# Altium schematic sheet ERM_OPTO_Long.SchDoc(ERM_OPTO_Long)
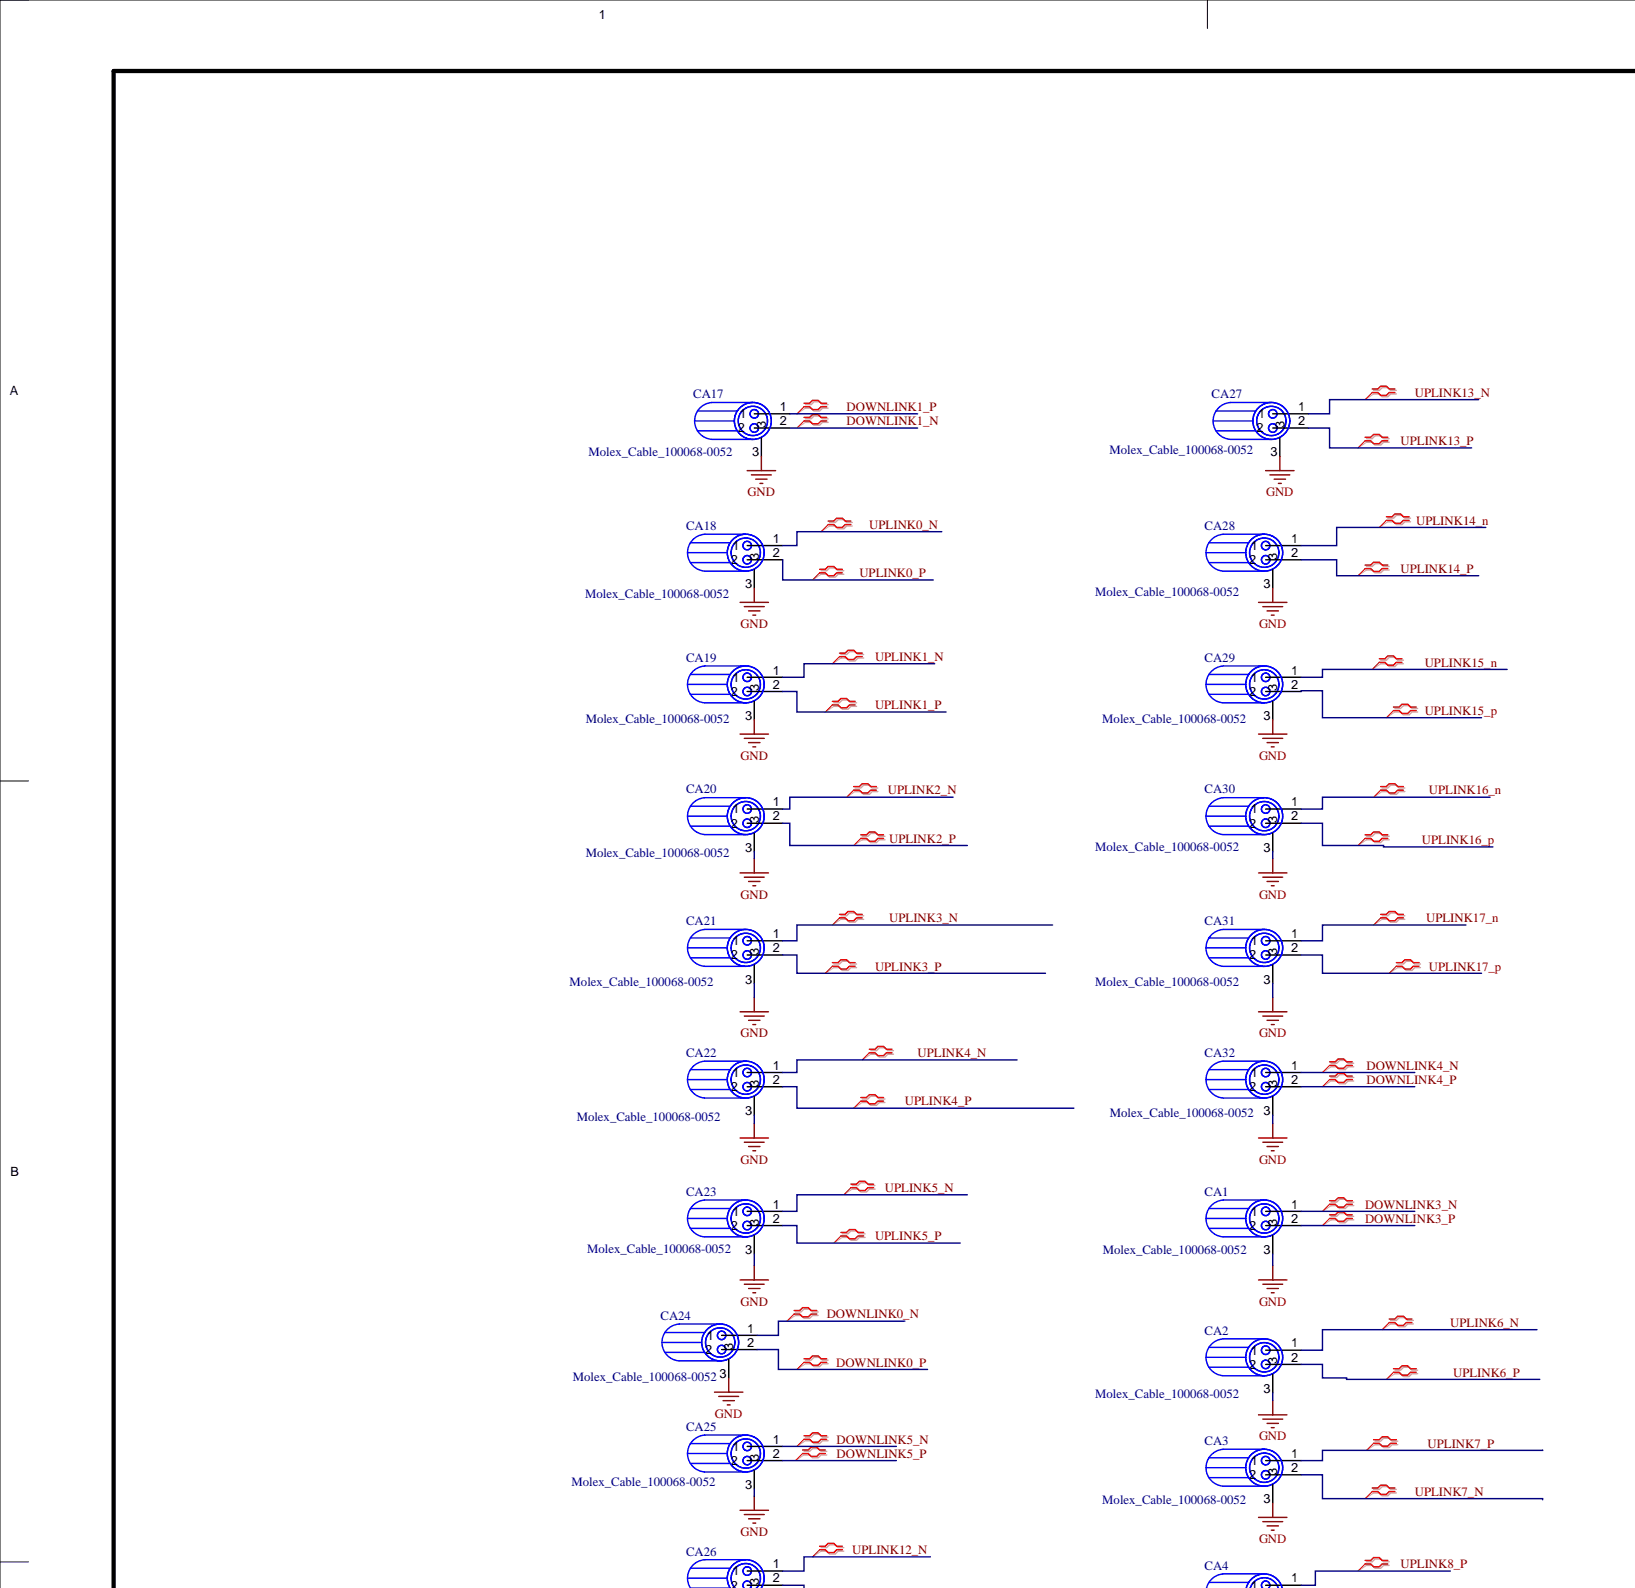
[diagram p0: ERM_OPTO_Long.SchDoc, top left]
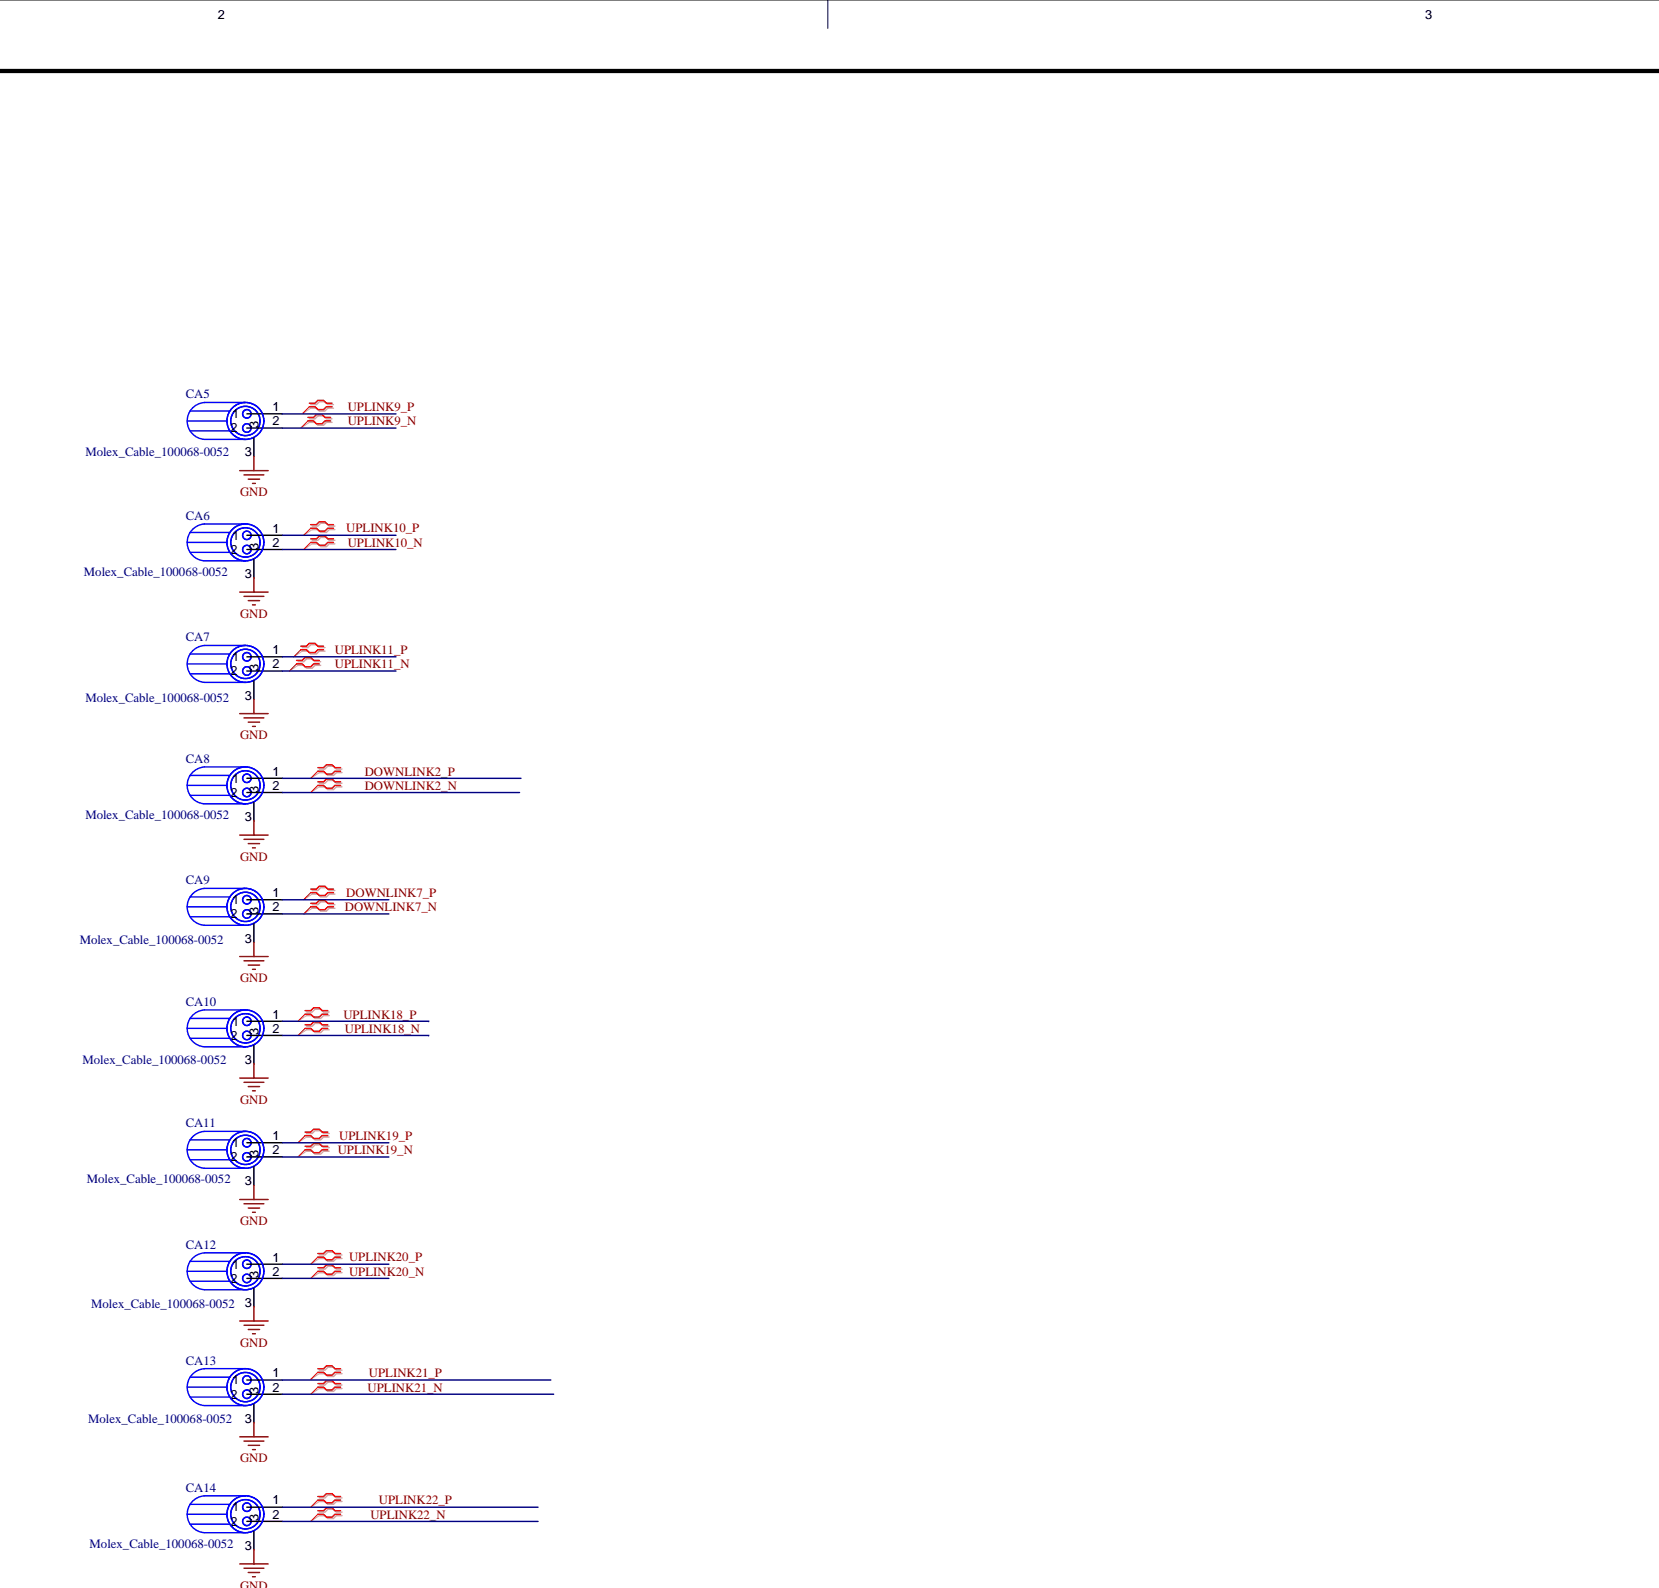
[diagram p1: ERM_OPTO_Long.SchDoc, top centre]
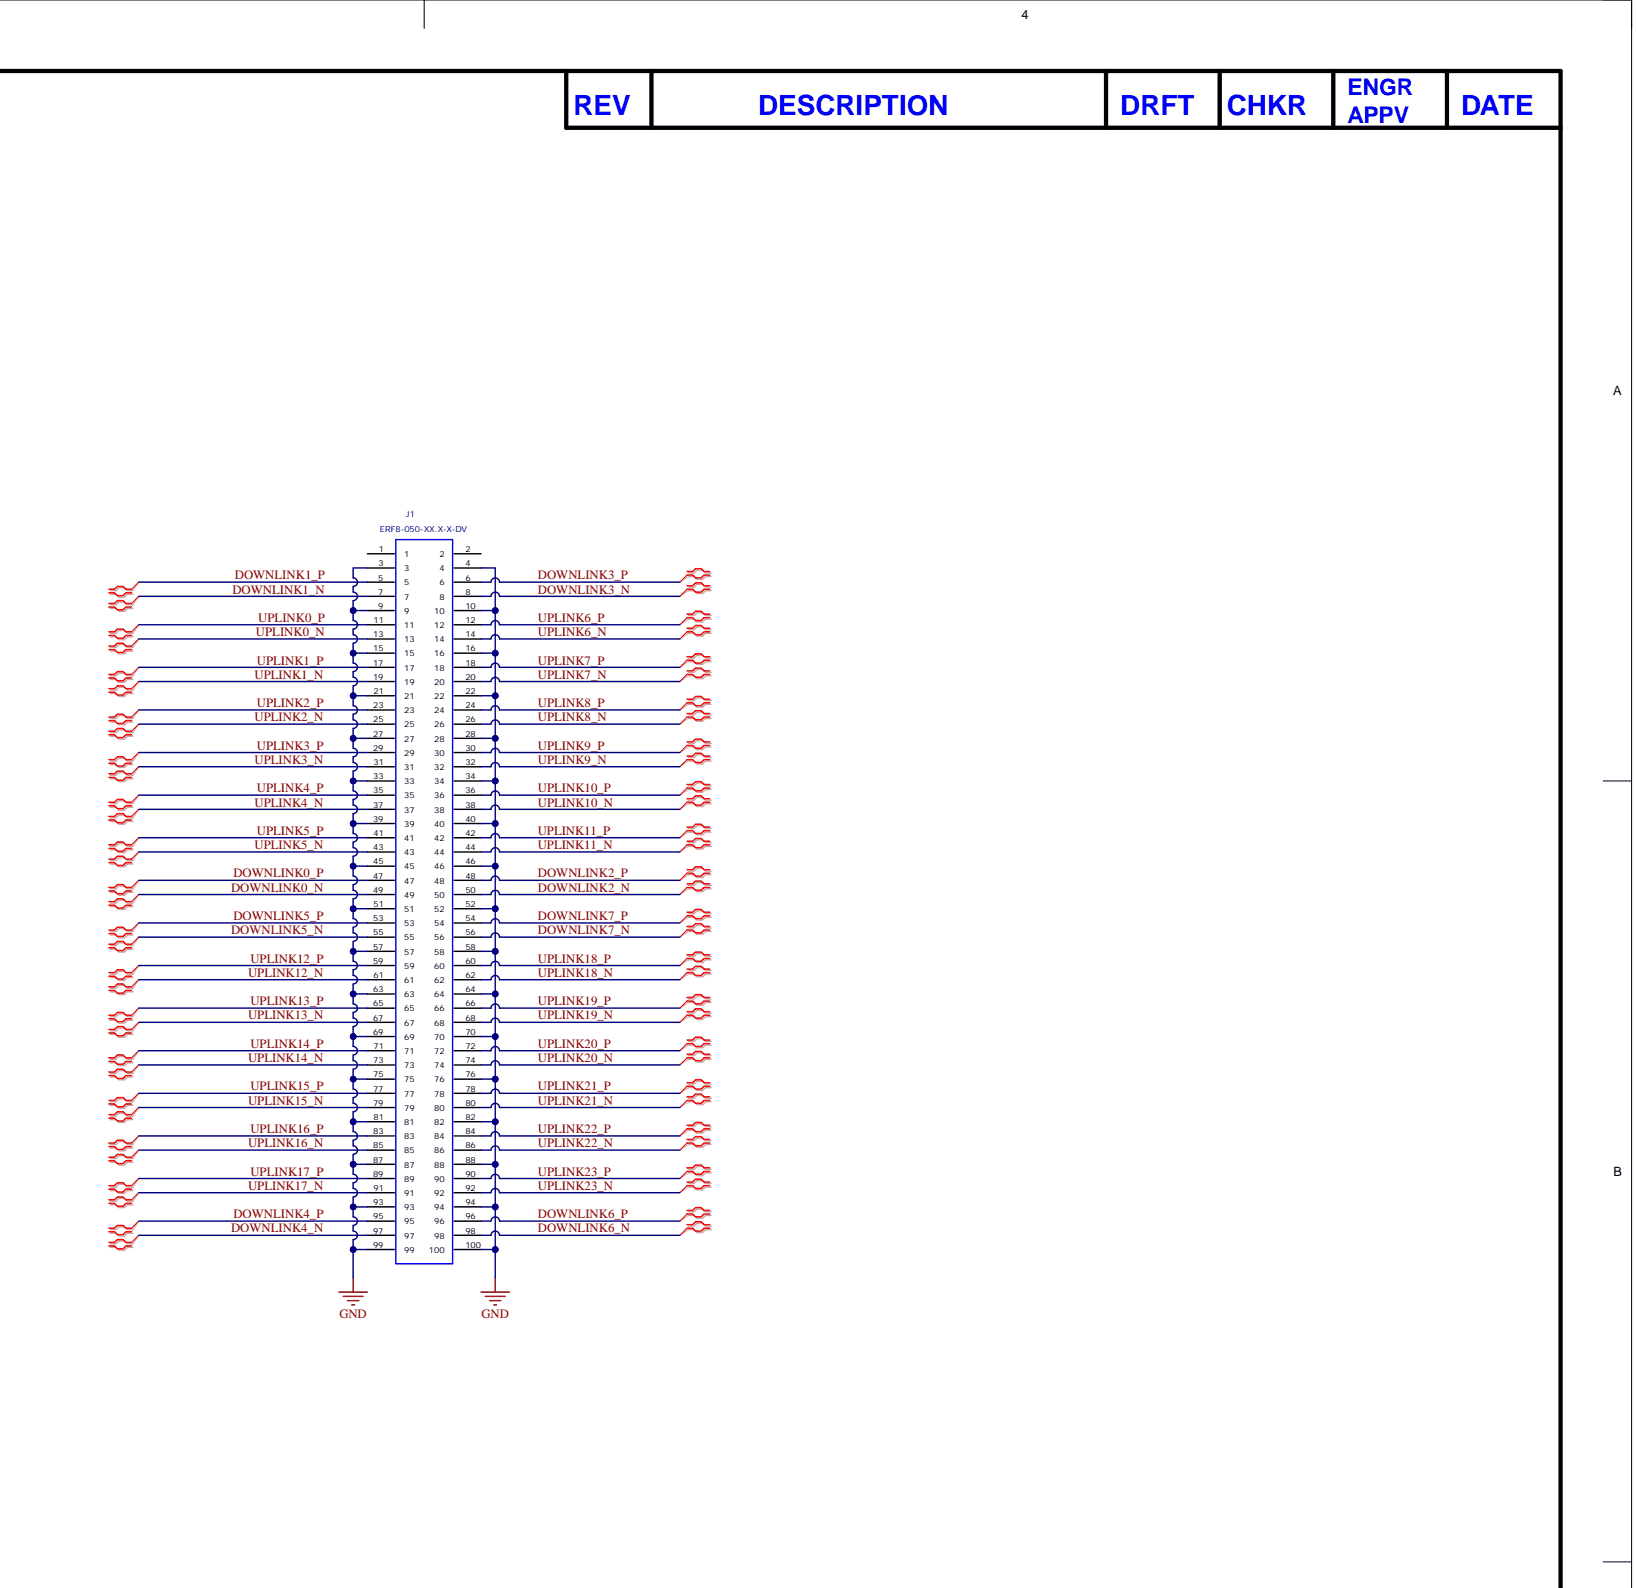
[diagram p2: ERM_OPTO_Long.SchDoc, top right]
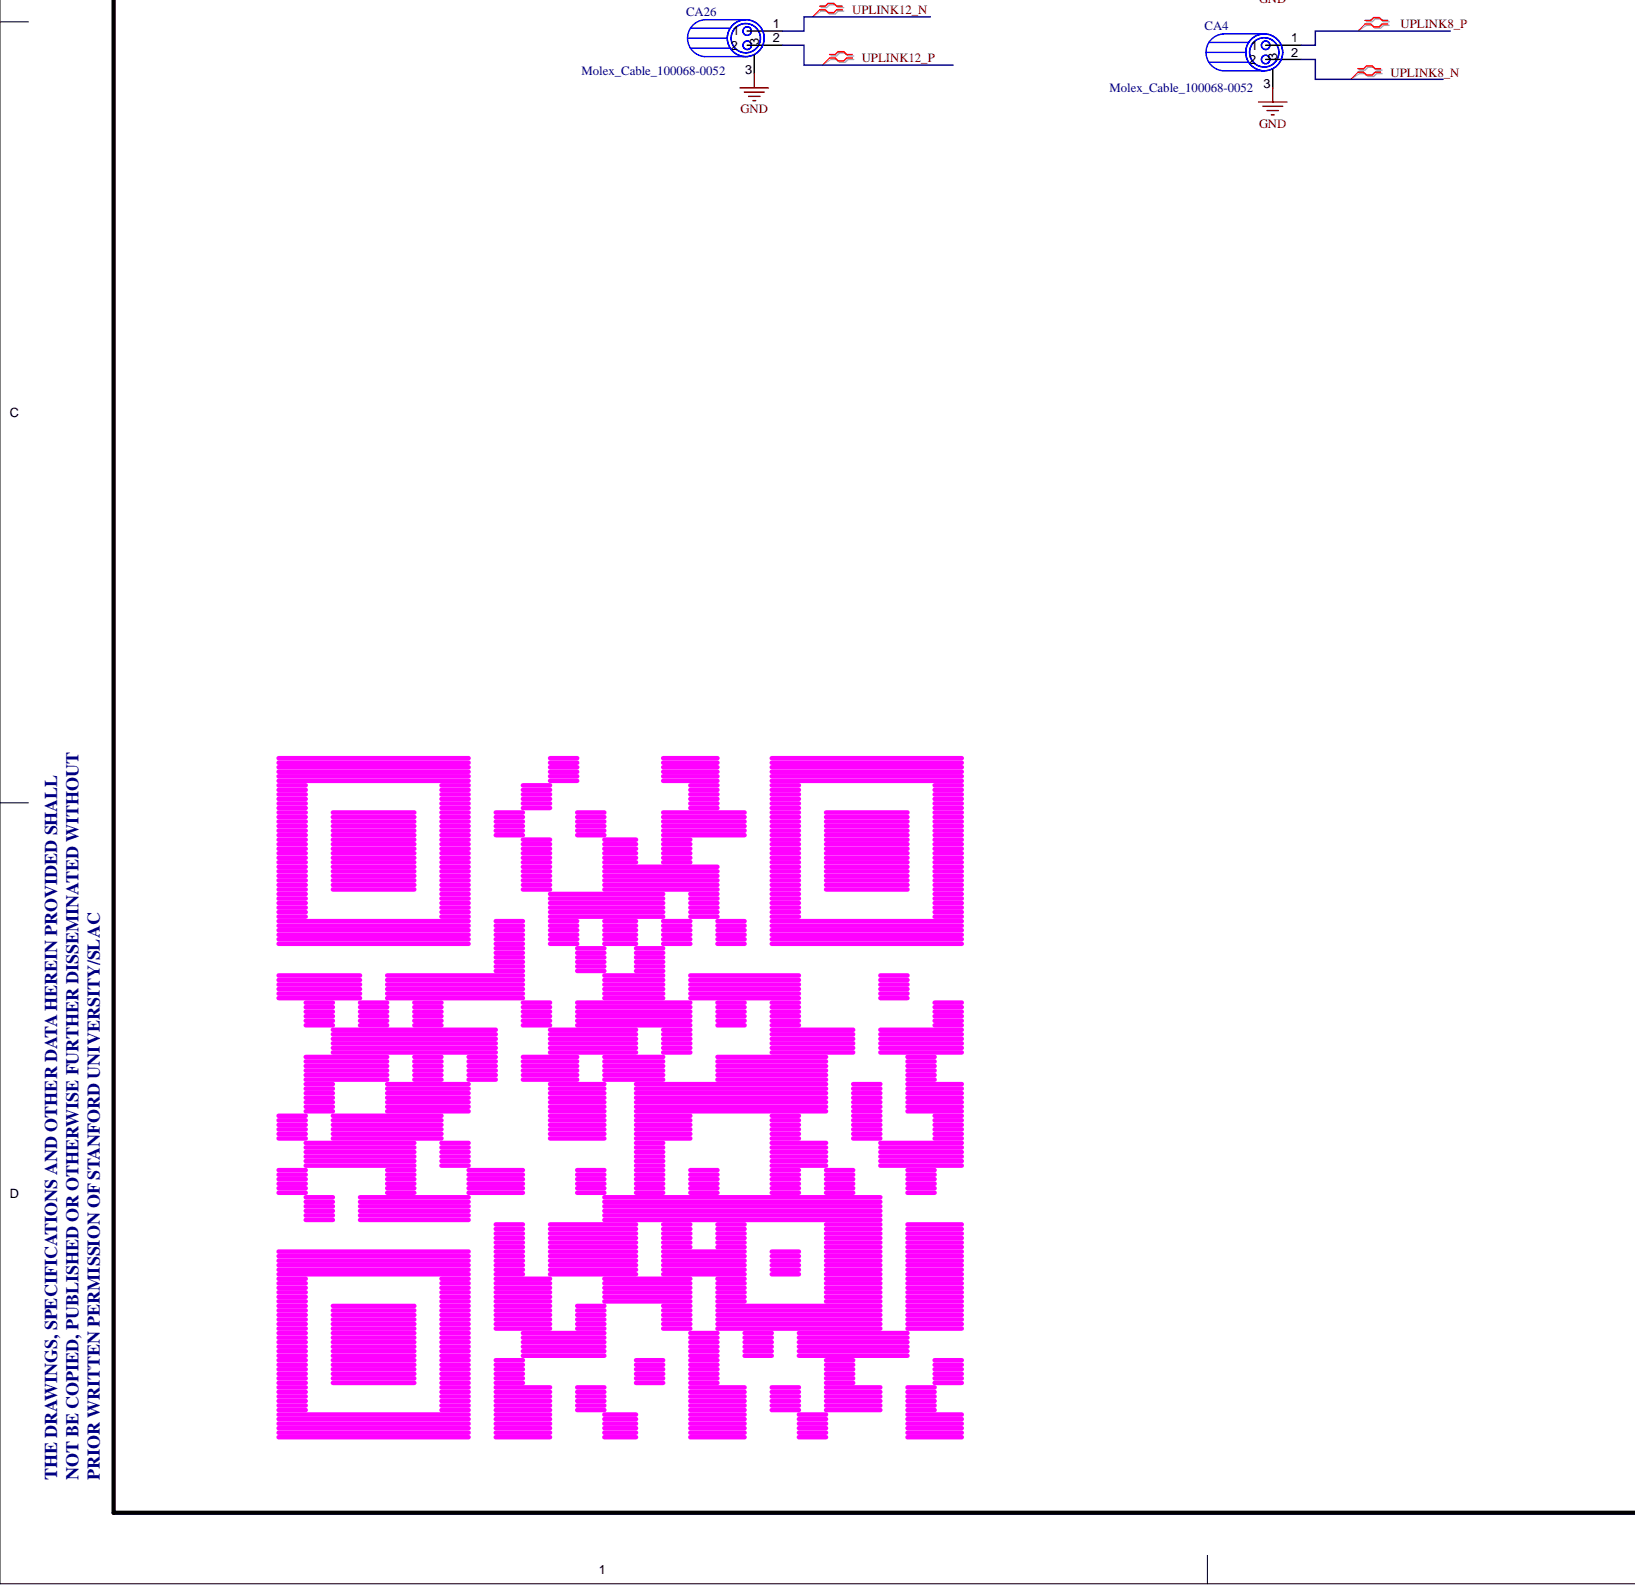
[diagram p3: ERM_OPTO_Long.SchDoc, bottom left]
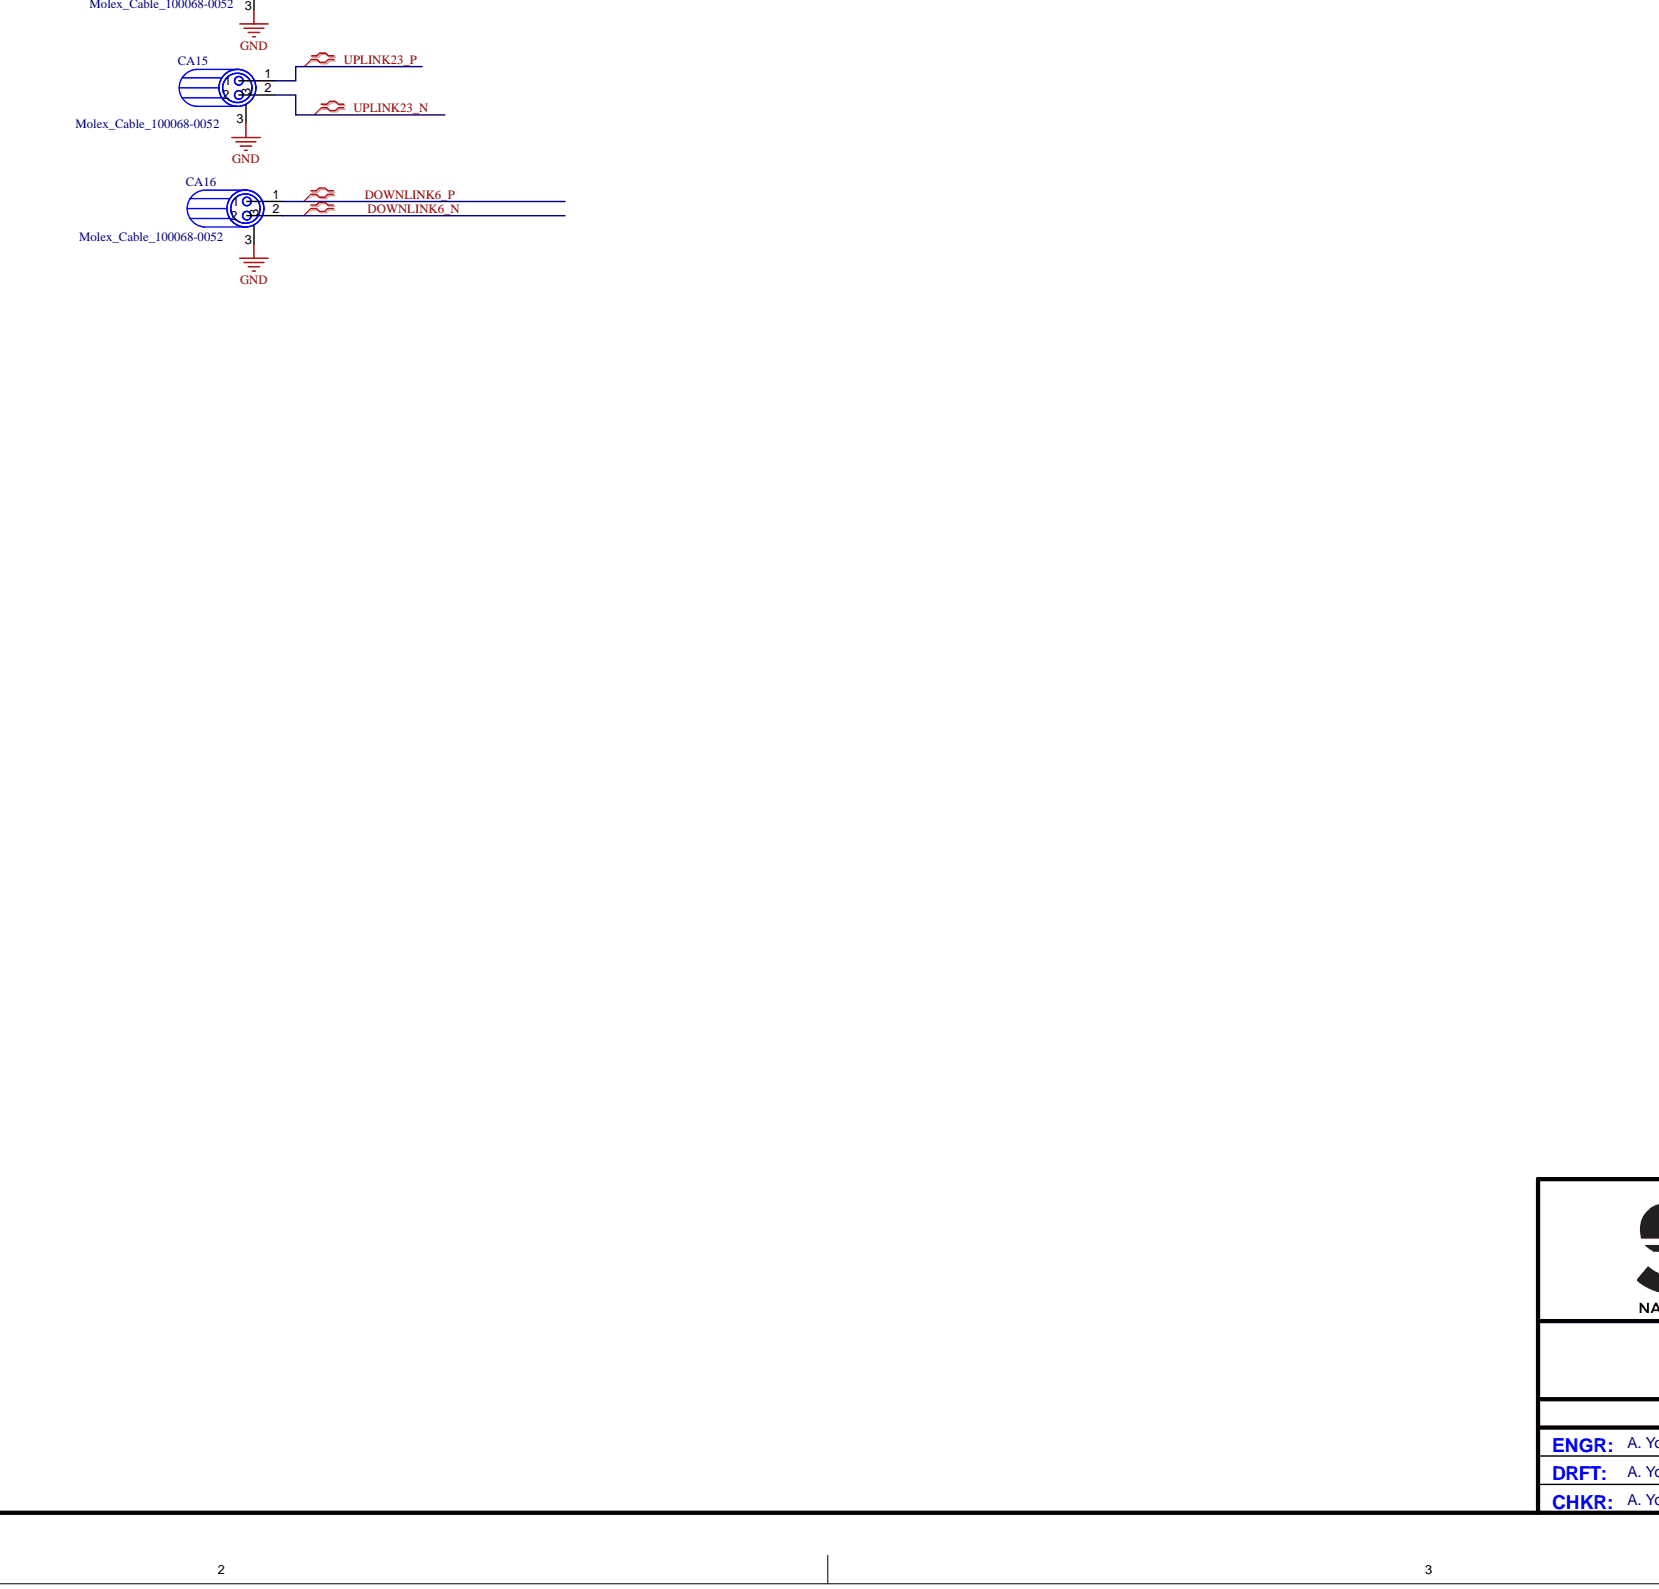
[diagram p4: ERM_OPTO_Long.SchDoc, bottom centre]
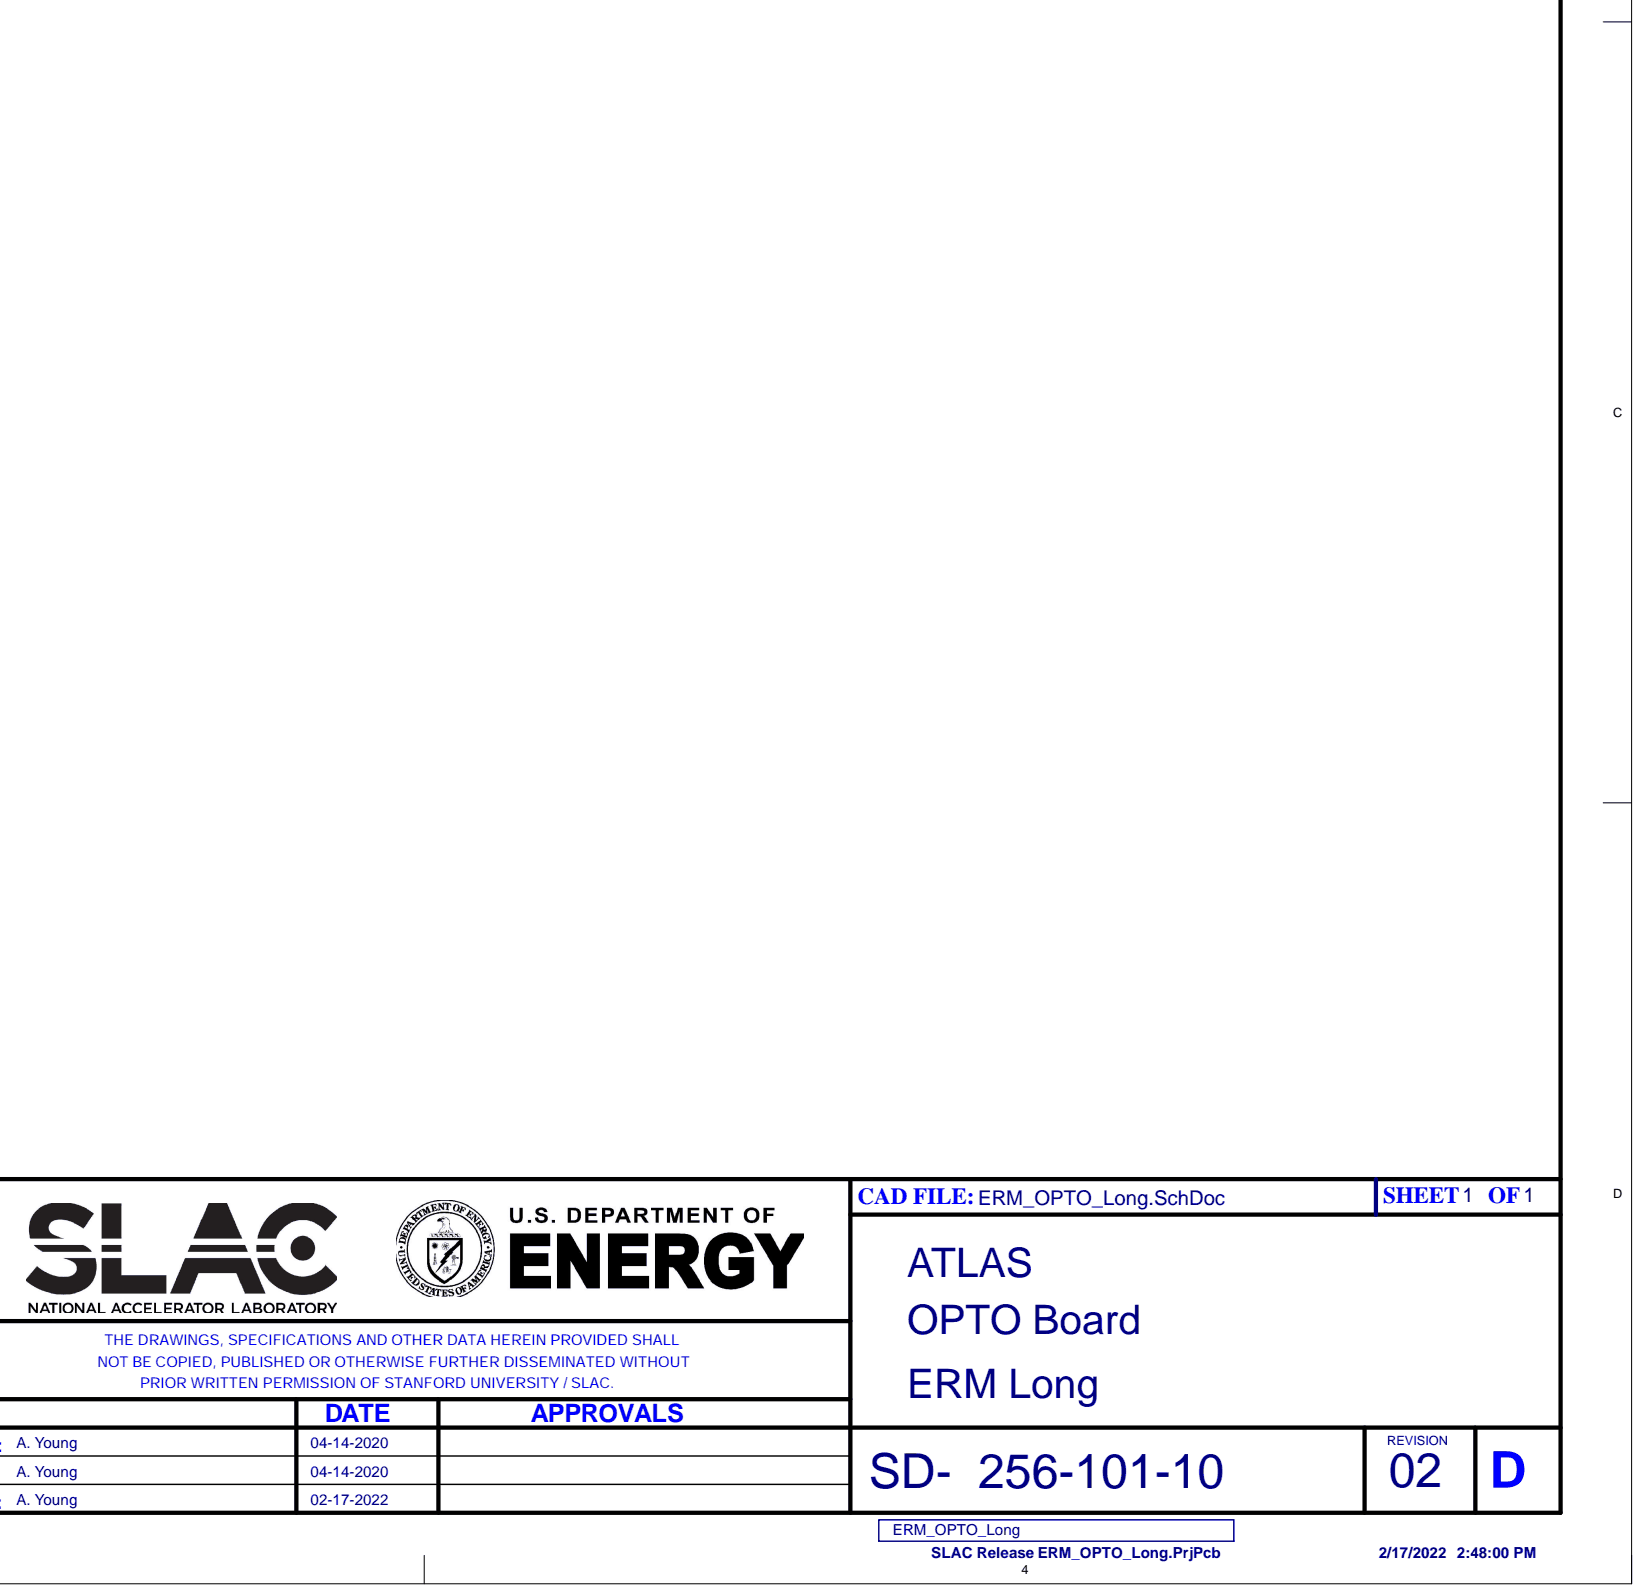
[diagram p5: ERM_OPTO_Long.SchDoc, bottom right]

## Parts
CA1  Molex_Cable_100068-0052  [Molex_Cable_100068-0052_No_Via]
CA2  Molex_Cable_100068-0052  [Molex_Cable_100068-0052_No_Via]
CA3  Molex_Cable_100068-0052  [Molex_Cable_100068-0052_No_Via]
CA4  Molex_Cable_100068-0052  [Molex_Cable_100068-0052_No_Via]
CA5  Molex_Cable_100068-0052  [Molex_Cable_100068-0052_No_Via]
CA6  Molex_Cable_100068-0052  [Molex_Cable_100068-0052_No_Via]
CA7  Molex_Cable_100068-0052  [Molex_Cable_100068-0052_No_Via]
CA8  Molex_Cable_100068-0052  [Molex_Cable_100068-0052_No_Via]
CA9  Molex_Cable_100068-0052  [Molex_Cable_100068-0052_No_Via]
CA10  Molex_Cable_100068-0052  [Molex_Cable_100068-0052_No_Via]
CA11  Molex_Cable_100068-0052  [Molex_Cable_100068-0052_No_Via]
CA12  Molex_Cable_100068-0052  [Molex_Cable_100068-0052_No_Via]
CA13  Molex_Cable_100068-0052  [Molex_Cable_100068-0052_No_Via]
CA14  Molex_Cable_100068-0052  [Molex_Cable_100068-0052_No_Via]
CA15  Molex_Cable_100068-0052  [Molex_Cable_100068-0052_No_Via]
CA16  Molex_Cable_100068-0052  [Molex_Cable_100068-0052_No_Via]
CA17  Molex_Cable_100068-0052  [Molex_Cable_100068-0052_No_Via]
CA18  Molex_Cable_100068-0052  [Molex_Cable_100068-0052_No_Via]
CA19  Molex_Cable_100068-0052  [Molex_Cable_100068-0052_No_Via]
CA20  Molex_Cable_100068-0052  [Molex_Cable_100068-0052_No_Via]
CA21  Molex_Cable_100068-0052  [Molex_Cable_100068-0052_No_Via]
CA22  Molex_Cable_100068-0052  [Molex_Cable_100068-0052_No_Via]
CA23  Molex_Cable_100068-0052  [Molex_Cable_100068-0052_No_Via]
CA24  Molex_Cable_100068-0052  [Molex_Cable_100068-0052_No_Via]
CA25  Molex_Cable_100068-0052  [Molex_Cable_100068-0052_No_Via]
CA26  Molex_Cable_100068-0052  [Molex_Cable_100068-0052_No_Via]
CA27  Molex_Cable_100068-0052  [Molex_Cable_100068-0052_No_Via]
CA28  Molex_Cable_100068-0052  [Molex_Cable_100068-0052_No_Via]
CA29  Molex_Cable_100068-0052  [Molex_Cable_100068-0052_No_Via]
CA30  Molex_Cable_100068-0052  [Molex_Cable_100068-0052_No_Via]
CA31  Molex_Cable_100068-0052  [Molex_Cable_100068-0052_No_Via]
CA32  Molex_Cable_100068-0052  [Molex_Cable_100068-0052_No_Via]
J1  ERF8-050-XX.X-X-DV  [ERF8-050-XX.X-X-DV]

## Nets
GND: CA1.3 CA2.3 CA3.3 CA4.3 CA5.3 CA6.3 CA7.3 CA8.3 CA9.3 CA10.3 CA11.3 CA12.3 CA13.3 CA14.3 CA15.3 CA16.3 CA17.3 CA18.3 CA19.3 CA20.3 CA21.3 CA22.3 CA23.3 CA24.3 CA25.3 CA26.3 CA27.3 CA28.3 CA29.3 CA30.3 CA31.3 CA32.3 J1.3 J1.4 J1.9 J1.10 J1.15 J1.16 J1.21 J1.22 J1.27 J1.28 J1.33 J1.34 J1.39 J1.40 J1.45 J1.46 J1.51 J1.52 J1.57 J1.58 J1.63 J1.64 J1.69 J1.70 J1.75 J1.76 J1.81 J1.82 J1.87 J1.88 J1.93 J1.94 J1.99 J1.100
DOWNLINK0_N: CA24.1 J1.49
DOWNLINK0_P: CA24.2 J1.47
DOWNLINK1_N: CA17.2 J1.7
DOWNLINK1_P: CA17.1 J1.5
DOWNLINK2_N: CA8.2 J1.50
DOWNLINK2_P: CA8.1 J1.48
DOWNLINK3_N: CA1.1 J1.8
DOWNLINK3_P: CA1.2 J1.6
DOWNLINK4_N: CA32.1 J1.97
DOWNLINK4_P: CA32.2 J1.95
DOWNLINK5_N: CA25.1 J1.55
DOWNLINK5_P: CA25.2 J1.53
DOWNLINK6_N: CA16.2 J1.98
DOWNLINK6_P: CA16.1 J1.96
DOWNLINK7_N: CA9.2 J1.56
DOWNLINK7_P: CA9.1 J1.54
UPLINK0_N: CA18.1 J1.13
UPLINK0_P: CA18.2 J1.11
UPLINK1_N: CA19.1 J1.19
UPLINK1_P: CA19.2 J1.17
UPLINK2_N: CA20.1 J1.25
UPLINK2_P: CA20.2 J1.23
UPLINK3_N: CA21.1 J1.31
UPLINK3_P: CA21.2 J1.29
UPLINK4_N: CA22.1 J1.37
UPLINK4_P: CA22.2 J1.35
UPLINK5_N: CA23.1 J1.43
UPLINK5_P: CA23.2 J1.41
UPLINK6_N: CA2.1 J1.14
UPLINK6_P: CA2.2 J1.12
UPLINK7_N: CA3.2 J1.20
UPLINK7_P: CA3.1 J1.18
UPLINK8_N: CA4.2 J1.26
UPLINK8_P: CA4.1 J1.24
UPLINK9_N: CA5.2 J1.32
UPLINK9_P: CA5.1 J1.30
UPLINK10_N: CA6.2 J1.38
UPLINK10_P: CA6.1 J1.36
UPLINK11_N: CA7.2 J1.44
UPLINK11_P: CA7.1 J1.42
UPLINK12_N: CA26.1 J1.61
UPLINK12_P: CA26.2 J1.59
UPLINK13_N: CA27.1 J1.67
UPLINK13_P: CA27.2 J1.65
UPLINK14_n: CA28.1 J1.73
UPLINK14_P: CA28.2 J1.71
UPLINK15_n: CA29.1 J1.79
UPLINK15_p: CA29.2 J1.77
UPLINK16_n: CA30.1 J1.85
UPLINK16_p: CA30.2 J1.83
UPLINK17_n: CA31.1 J1.91
UPLINK17_p: CA31.2 J1.89
UPLINK18_N: CA10.2 J1.62
UPLINK18_P: CA10.1 J1.60
UPLINK19_N: CA11.2 J1.68
UPLINK19_P: CA11.1 J1.66
UPLINK20_N: CA12.2 J1.74
UPLINK20_P: CA12.1 J1.72
UPLINK21_N: CA13.2 J1.80
UPLINK21_P: CA13.1 J1.78
UPLINK22_N: CA14.2 J1.86
UPLINK22_P: CA14.1 J1.84
UPLINK23_N: CA15.2 J1.92
UPLINK23_P: CA15.1 J1.90

Not connected: J1 1 2

## Library data of the parts
CA1-CA32 = Molex_Cable_100068-0052_No_Via: Molex; 100068-0052, Twinax Cable 34AWG 100 Ohms; Unit_Price_Static: 25.00; Component Kind: Standard; Manufacturer: Molex; Manufacturer Part Number: 100068-0052; Package / Case: Molex_100068-0052; Library Name: yee_sch_lib.SchLib
J1 = ERF8-050-XX.X-X-DV: Socket Assembly, Double Row Vertical, Surface Mount, 0.8mm Pitch, 100 Pins; MANUFACTURER: SAMTEC; Manufacturer Part Number: ERF8-050-05.0-S-DV; Unit_Price_Static: 7.00; Component Kind: Standard; Package / Case: ERF8-050-05.0-S-DV; PCB DECAL: ERF8-050-XX.X-X-DV; CREATED: October 23, 2014 - Wendy Xin; Library Name: TerminationBoardLib.SchLib
